# Altium schematic sheet EXTconnect.SchDoc
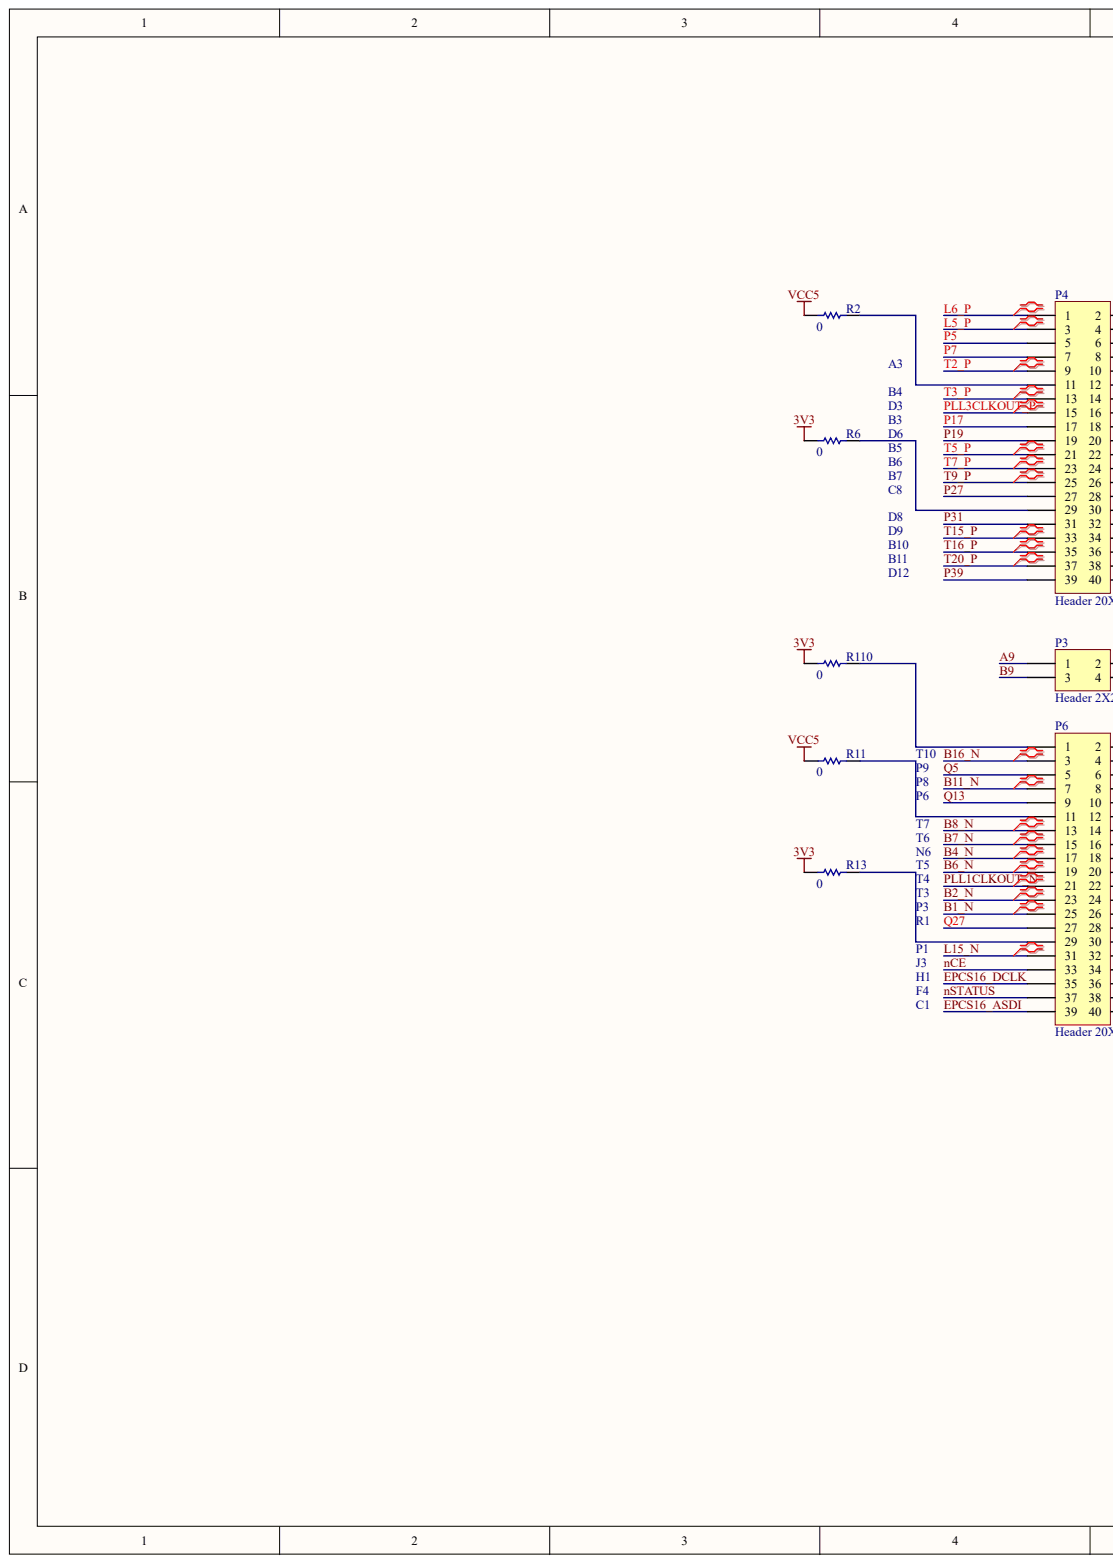
[diagram p0: EXTconnect.SchDoc, left]
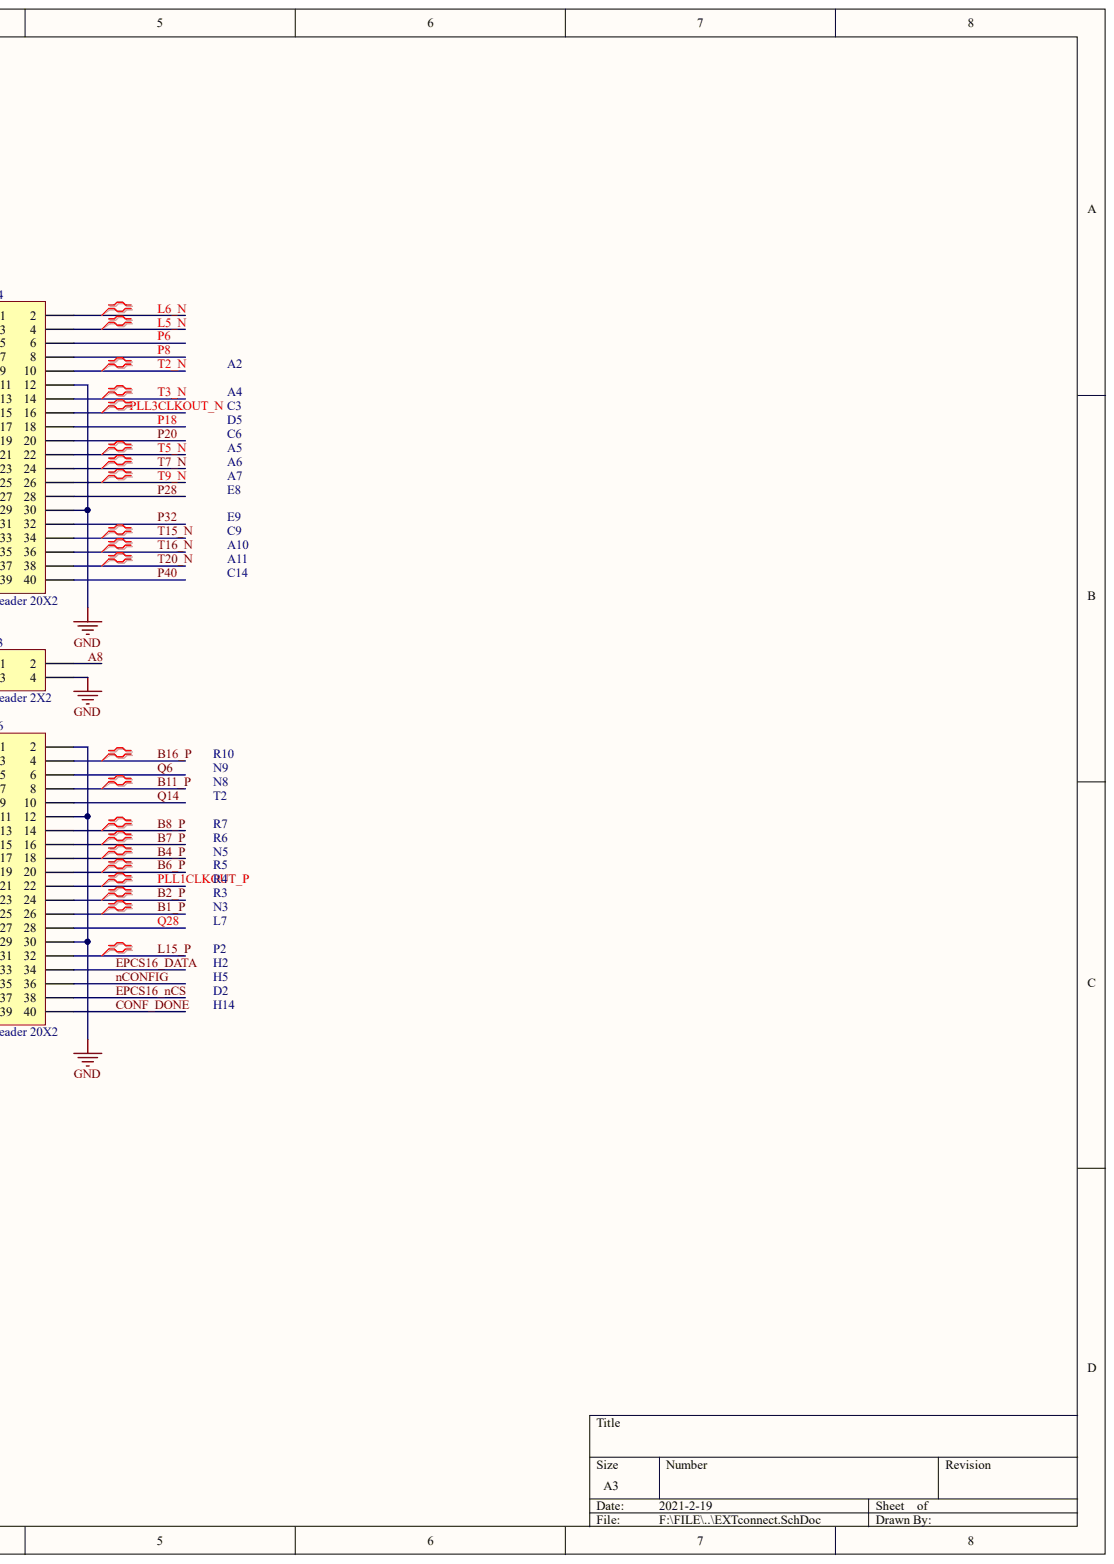
[diagram p1: EXTconnect.SchDoc, right]

## Parts
P3  Header 2X2  [HDR2X2]
P4  Header 20X2  [HDR2X20]
P6  Header 20X2  [HDR2X20]
R2  0  [0603_Q0603A]
R6  0  [0603_Q0603A]
R11  0  [0603_Q0603A]
R13  0  [0603_Q0603A]
R110  0  [0603_Q0603A]

## Nets
3V3: R6.1 R13.1 R110.1
GND: P3.4 P4.12 P4.30 P6.2 P6.12 P6.30
VCC5: R2.1 R11.1
A8: P3.2
A9: P3.1
B1_N: P6.25
B1_P: P6.26
B2_N: P6.23
B2_P: P6.24
B4_N: P6.17
B4_P: P6.18
B6_N: P6.19
B6_P: P6.20
B7_N: P6.15
B7_P: P6.16
B8_N: P6.13
B8_P: P6.14
B9: P3.3
B11_N: P6.7
B11_P: P6.8
B16_N: P6.3
B16_P: P6.4
CONF_DONE: P6.40
EPCS16_ASDI: P6.39
EPCS16_DATA: P6.34
EPCS16_DCLK: P6.35
EPCS16_NCS: P6.38
L5_N: P4.4
L5_P: P4.3
L6_N: P4.2
L6_P: P4.1
L15_N: P6.31
L15_P: P6.32
NCE: P6.33
NCONFIG: P6.36
NSTATUS: P6.37
P5: P4.5
P6: P4.6
P7: P4.7
P8: P4.8
P17: P4.17
P18: P4.18
P19: P4.19
P20: P4.20
P27: P4.27
P28: P4.28
P31: P4.31
P32: P4.32
P39: P4.39
P40: P4.40
PLL1CLKOUT_N: P6.21
PLL1CLKOUT_P: P6.22
PLL3CLKOUT_N: P4.16
PLL3CLKOUT_P: P4.15
Q5: P6.5
Q6: P6.6
Q13: P6.9
Q14: P6.10
Q27: P6.27
Q28: P6.28
T2_N: P4.10
T2_P: P4.9
T3_N: P4.14
T3_P: P4.13
T5_N: P4.22
T5_P: P4.21
T7_N: P4.24
T7_P: P4.23
T9_N: P4.26
T9_P: P4.25
T15_N: P4.34
T15_P: P4.33
T16_N: P4.36
T16_P: P4.35
T20_N: P4.38
T20_P: P4.37
NetP4_11: P4.11 R2.2
NetP4_29: P4.29 R6.2
NetP6_1: P6.1 R110.2
NetP6_11: P6.11 R11.2
NetP6_29: P6.29 R13.2

## Library data of the parts
P3 = Header 2X2: Header, 2-Pin, Dual row; Component Kind: Standard; LatestRevisionDate: 17-Jul-2002; LatestRevisionNote: Re-released for DXP Platform.; Library Name: Miscellaneous Connectors.IntLib; Signal Integrity: Connector; Publisher: Altium Limited
P4 P6 = Header 20X2: Header, 20-Pin, Dual row; Component Kind: Standard; LatestRevisionDate: 17-Jul-2002; LatestRevisionNote: Re-released for DXP Platform.; Library Name: Miscellaneous Connectors.IntLib; Signal Integrity: Connector; Publisher: Altium Limited
R2 R6 R11 R13 R110 = RES: Component Kind: Standard; Library Name: the_god_of_death.IntLib
